# Altium schematic sheet Main_Schematic.SchDoc
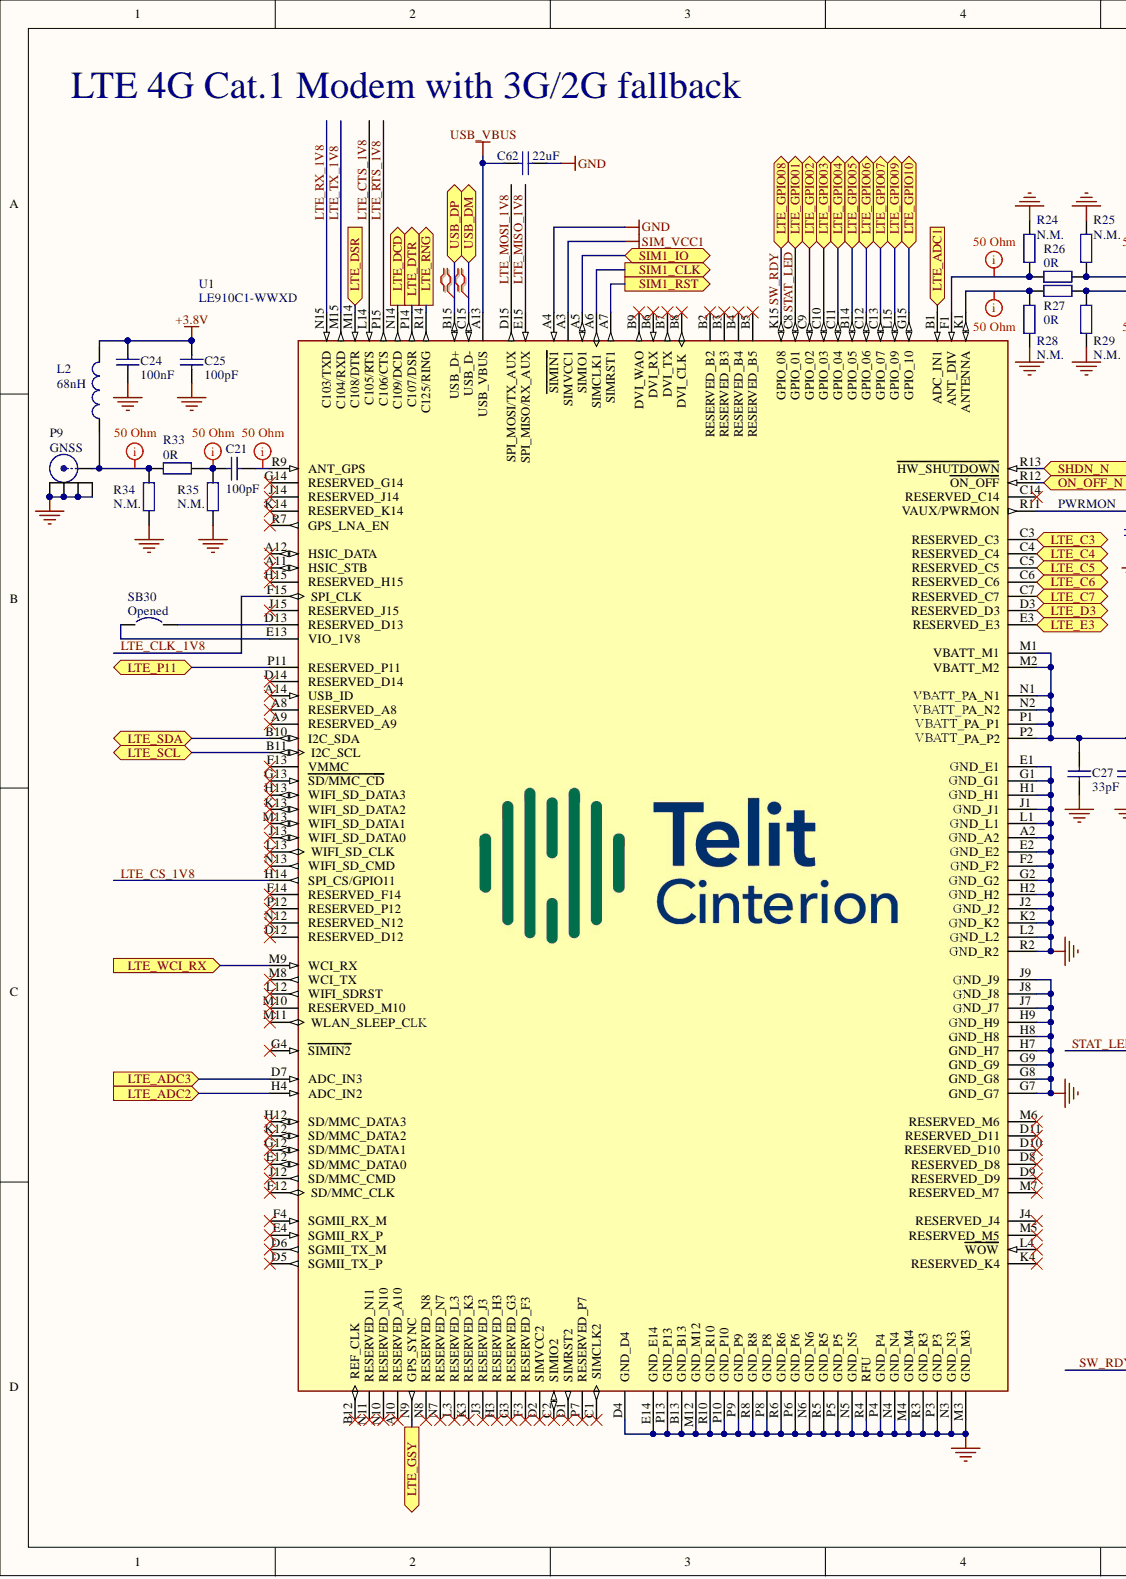
[diagram p0: Main_Schematic.SchDoc, left]
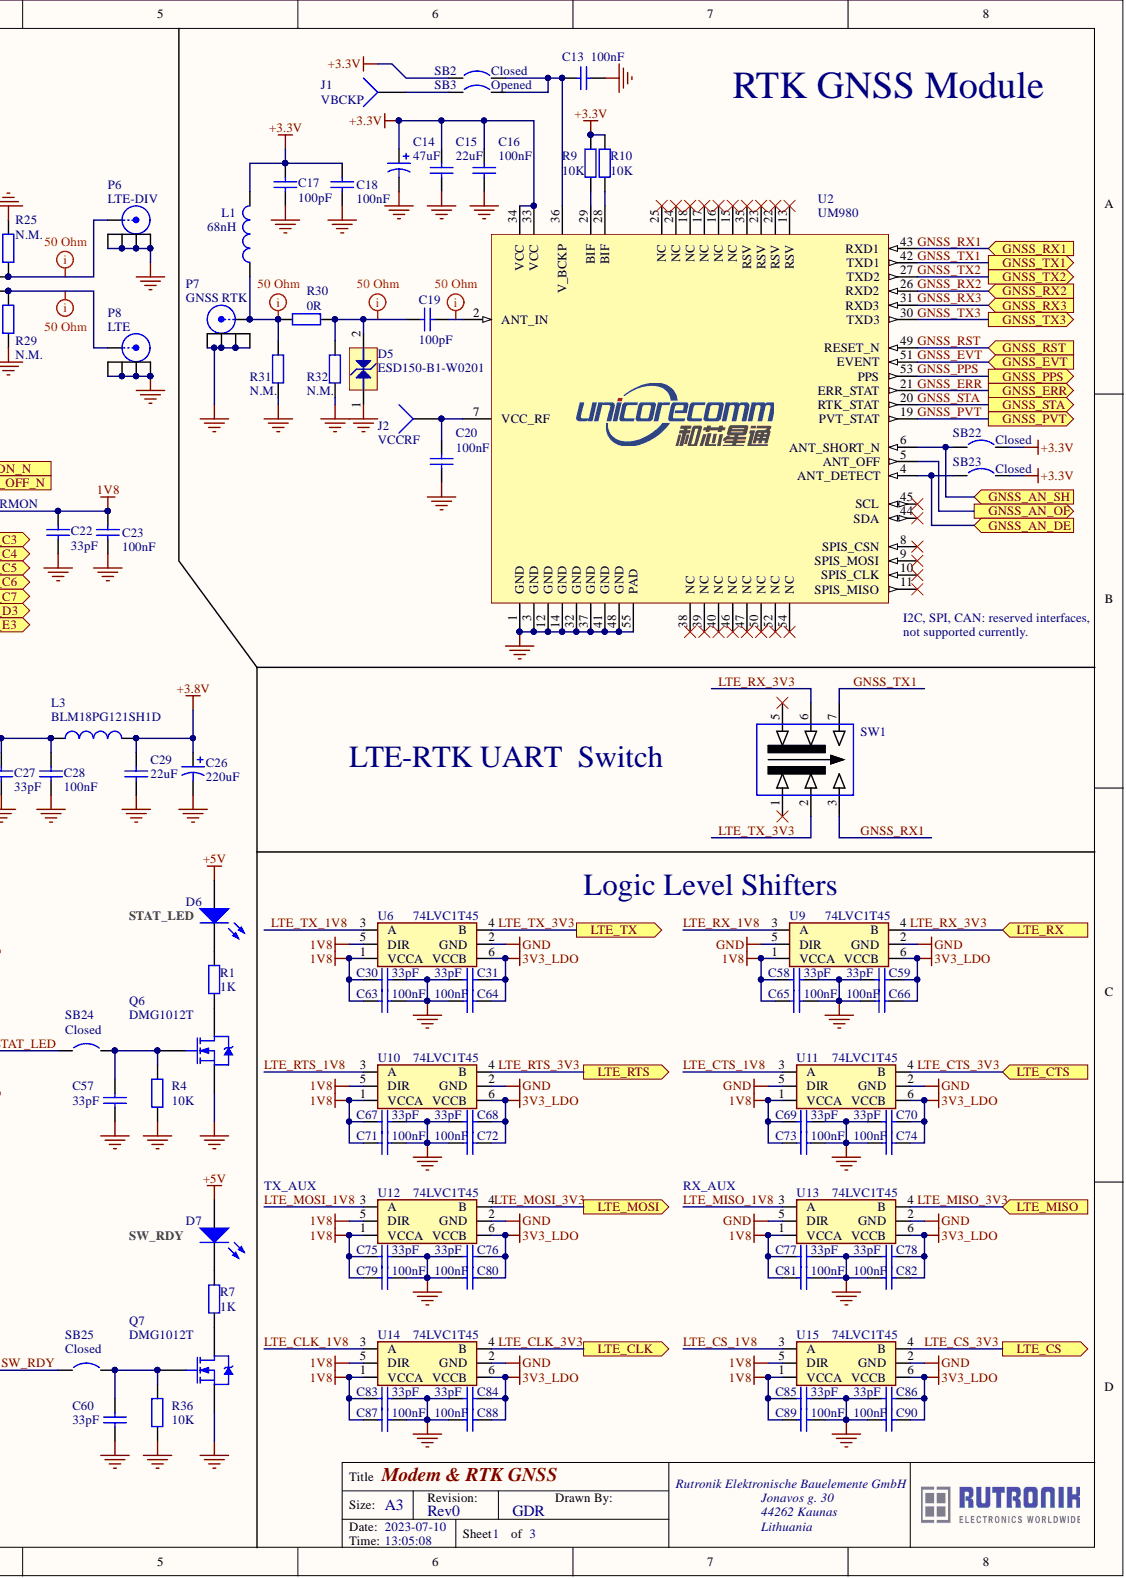
[diagram p1: Main_Schematic.SchDoc, right]

## Parts
C13  value 100nF  [C0402]  (hidden comment: Cap Semi)
C14  value 47uF  [Case-B]
C15  value 22uF  [C0805]  (hidden comment: Cap Semi)
C16  value 100nF  [C0402]  (hidden comment: Cap Semi)
C17  value 100pF  [C0402]  (hidden comment: Cap Semi)
C18  value 100nF  [C0402]  (hidden comment: Cap Semi)
C19  value 100pF  [C0402]  (hidden comment: Cap Semi)
C20  value 100nF  [C0402]  (hidden comment: Cap Semi)
C21  value 100pF  [C0402]  (hidden comment: Cap Semi)
C22  value 33pF  [C0402]  (hidden comment: Cap Semi)
C23  value 100nF  [C0402]  (hidden comment: Cap Semi)
C24  value 100nF  [C0402]  (hidden comment: Cap Semi)
C25  value 100pF  [C0402]  (hidden comment: Cap Semi)
C26  value 220uF  [Case-B]  (hidden comment: electrolytic capacitor)
C27  value 33pF  [C0402]  (hidden comment: Cap Semi)
C28  value 100nF  [C0402]  (hidden comment: Cap Semi)
C29  value 22uF  [C0805]  (hidden comment: Cap Semi)
C30  value 33pF  [C0402]  (hidden comment: Cap Semi)
C31  value 33pF  [C0402]  (hidden comment: Cap Semi)
C57  value 33pF  [C0402]  (hidden comment: Cap Semi)
C58  value 33pF  [C0402]  (hidden comment: Cap Semi)
C59  value 33pF  [C0402]  (hidden comment: Cap Semi)
C60  value 33pF  [C0402]  (hidden comment: Cap Semi)
C62  value 22uF  [C0805]  (hidden comment: Cap Semi)
C63  value 100nF  [C0402]  (hidden comment: Cap Semi)
C64  value 100nF  [C0402]  (hidden comment: Cap Semi)
C65  value 100nF  [C0402]  (hidden comment: Cap Semi)
C66  value 100nF  [C0402]  (hidden comment: Cap Semi)
C67  value 33pF  [C0402]  (hidden comment: Cap Semi)
C68  value 33pF  [C0402]  (hidden comment: Cap Semi)
C69  value 33pF  [C0402]  (hidden comment: Cap Semi)
C70  value 33pF  [C0402]  (hidden comment: Cap Semi)
C71  value 100nF  [C0402]  (hidden comment: Cap Semi)
C72  value 100nF  [C0402]  (hidden comment: Cap Semi)
C73  value 100nF  [C0402]  (hidden comment: Cap Semi)
C74  value 100nF  [C0402]  (hidden comment: Cap Semi)
C75  value 33pF  [C0402]  (hidden comment: Cap Semi)
C76  value 33pF  [C0402]  (hidden comment: Cap Semi)
C77  value 33pF  [C0402]  (hidden comment: Cap Semi)
C78  value 33pF  [C0402]  (hidden comment: Cap Semi)
C79  value 100nF  [C0402]  (hidden comment: Cap Semi)
C80  value 100nF  [C0402]  (hidden comment: Cap Semi)
C81  value 100nF  [C0402]  (hidden comment: Cap Semi)
C82  value 100nF  [C0402]  (hidden comment: Cap Semi)
C83  value 33pF  [C0402]  (hidden comment: Cap Semi)
C84  value 33pF  [C0402]  (hidden comment: Cap Semi)
C85  value 33pF  [C0402]  (hidden comment: Cap Semi)
C86  value 33pF  [C0402]  (hidden comment: Cap Semi)
C87  value 100nF  [C0402]  (hidden comment: Cap Semi)
C88  value 100nF  [C0402]  (hidden comment: Cap Semi)
C89  value 100nF  [C0402]  (hidden comment: Cap Semi)
C90  value 100nF  [C0402]  (hidden comment: Cap Semi)
D5  ESD150-B1-W0201  [SG-WLL-2]
D6  LED  [KG_DELLS_GREEN]  (value not drawn)
D7  LED  [KG_DELLS_GREEN]  (value not drawn)
J1  VBCKP  [PIN1]  (no BOM)
J2  VCCRF  [PIN1]  (no BOM)
L1  value 68nH  [L0402]  (hidden comment: Inductor)
L2  value 68nH  [L0402]  (hidden comment: Inductor)
L3  value BLM18PG121SH1D  Manufacturer Part No.: BLM18PG121SH1D  [L0603]  (hidden comment: Inductor)
P6  LTE-DIV  [73391-0083]
P7  GNSS RTK  [73391-0083]
P8  LTE  [73391-0083]
P9  GNSS  [73391-0083]
Q6  DMG1012T  [SOT-523_custom]
Q7  DMG1012T  [SOT-523_custom]
R1  value 1K  [R0402]  (hidden comment: Res2)
R4  value 10K  [R0402]  (hidden comment: Res2)
R7  value 1K  [R0402]  (hidden comment: Res2)
R9  value 10K  [R0402]  (hidden comment: Res2)
R10  value 10K  [R0402]  (hidden comment: Res2)
R24  value N.M.  [R0402]  (hidden comment: Res2)  (no BOM)
R25  value N.M.  [R0402]  (hidden comment: Res2)  (no BOM)
R26  value 0R  [R0402]  (hidden comment: Res2)
R27  value 0R  [R0402]  (hidden comment: Res2)
R28  value N.M.  [R0402]  (hidden comment: Res2)  (no BOM)
R29  value N.M.  [R0402]  (hidden comment: Res2)  (no BOM)
R30  value 0R  [R0402]  (hidden comment: Res2)
R31  value N.M.  [R0402]  (hidden comment: Res2)  (no BOM)
R32  value N.M.  [R0402]  (hidden comment: Res2)  (no BOM)
R33  value 0R  [R0402]  (hidden comment: Res2)
R34  value N.M.  [R0402]  (hidden comment: Res2)  (no BOM)
R35  value N.M.  [R0402]  (hidden comment: Res2)  (no BOM)
R36  value 10K  [R0402]  (hidden comment: Res2)
SB2  Closed  [SB_0402]
SB3  Opened  [SB_0402 - open]  (no BOM)
SB22  Closed  [SB_0402]
SB23  Closed  [SB_0402]
SB24  Closed  [SB_0402]
SB25  Closed  [SB_0402]
SB30  Opened  [SB_0402 - open]  (no BOM)
SW1  JS202011JCQN  [JS202011JCQN]  (value not drawn)
U1  LE910C1-WWXD  [XCVR_LE910C1WX03T037100]
U2  UM980  [UM980]
U6  74LVC1T45  [X2-DFN1410-6]
U9  74LVC1T45  [X2-DFN1410-6]
U10  74LVC1T45  [X2-DFN1410-6]
U11  74LVC1T45  [X2-DFN1410-6]
U12  74LVC1T45  [X2-DFN1410-6]
U13  74LVC1T45  [X2-DFN1410-6]
U14  74LVC1T45  [X2-DFN1410-6]
U15  74LVC1T45  [X2-DFN1410-6]

## Ports
GNSS_AN_DE, GNSS_AN_OF, GNSS_AN_SH, GNSS_ERR, GNSS_EVT, GNSS_PPS, GNSS_PVT, GNSS_RST, GNSS_RX1, GNSS_RX2, GNSS_RX3, GNSS_STA, GNSS_TX1, GNSS_TX2, GNSS_TX3, LTE_ADC1, LTE_ADC2, LTE_ADC3, LTE_C3, LTE_C4, LTE_C5, LTE_C6, LTE_C7, LTE_CLK, LTE_CS, LTE_CTS, LTE_D3, LTE_DCD, LTE_DSR, LTE_DTR, LTE_E3, LTE_GPIO01, LTE_GPIO02, LTE_GPIO03, LTE_GPIO04, LTE_GPIO05, LTE_GPIO06, LTE_GPIO07, LTE_GPIO08, LTE_GPIO09, LTE_GPIO10, LTE_GSY, LTE_MISO, LTE_MOSI, LTE_P11, LTE_RNG, LTE_RTS, LTE_RX, LTE_SCL, LTE_SDA, LTE_TX, LTE_WCI_RX, ON_OFF_N, SHDN_N, SIM1_CLK, SIM1_IO, SIM1_RST, USB_DM, USB_DP

## Nets
1V8: C22.2 C23.1 C30.2 C58.2 C63.1 C65.1 C67.2 C69.2 C71.1 C73.1 C75.2 C77.2 C79.1 C81.1 C83.2 C85.2 C87.1 C89.1 U1.R11(VAUX/PWRMON) U6.1(VCCA) U6.5(DIR) U9.1(VCCA) U10.1(VCCA) U10.5(DIR) U11.1(VCCA) U12.1(VCCA) U12.5(DIR) U13.1(VCCA) U14.1(VCCA) U14.5(DIR) U15.1(VCCA) U15.5(DIR)
3V3_LDO: C31.1 C59.1 C64.2 C66.2 C68.1 C70.1 C72.2 C74.2 C76.1 C78.1 C80.2 C82.2 C84.1 C86.1 C88.2 C90.2 U6.6(VCCB) U9.6(VCCB) U10.6(VCCB) U11.6(VCCB) U12.6(VCCB) U13.6(VCCB) U14.6(VCCB) U15.6(VCCB)
+3.3V: C14.1 C15.2 C16.1 C17.2 C18.1 L1.2 R9.1 R10.1 SB2.1 SB22.2 SB23.2 U2.33(VCC) U2.34(VCC)
+3.8V: C24.1 C25.2 C26.1 C29.2 L2.2 L3.2
+5V: D6.1 D7.1
GND: C13.2 C14.2 C15.1 C16.2 C17.1 C18.2 C20.2 C22.1 C23.2 C24.2 C25.1 C26.2 C27.1 C28.1 C29.1 C30.1 C31.2 C57.2 C58.1 C59.2 C60.2 C62.1 C63.2 C64.1 C65.2 C66.1 C67.1 C68.2 C69.1 C70.2 C71.2 C72.1 C73.2 C74.1 C75.1 C76.2 C77.1 C78.2 C79.2 C80.1 C81.2 C82.1 C83.1 C84.2 C85.1 C86.2 C87.2 C88.1 C89.2 C90.1 D5.1 P6.2 P6.3 P6.4 P6.5 P7.2 P7.3 P7.4 P7.5 P8.2 P8.3 P8.4 P8.5 P9.2 P9.3 P9.4 P9.5 Q6.3 Q7.3 R4.1 R24.2 R25.2 R28.2 R29.2 R31.2 R32.2 R34.2 R35.2 R36.1 U1.A2(GND_A2) U1.A4(SIMIN1) U1.B13(GND_B13) U1.D4(GND_D4) U1.E1(GND_E1) U1.E2(GND_E2) U1.E14(GND_E14) U1.F2(GND_F2) U1.G1(GND_G1) U1.G2(GND_G2) U1.G7(GND_G7) U1.G8(GND_G8) U1.G9(GND_G9) U1.H1(GND_H1) U1.H2(GND_H2) U1.H7(GND_H7) U1.H8(GND_H8) U1.H9(GND_H9) U1.J1(GND_J1) U1.J2(GND_J2) U1.J7(GND_J7) U1.J8(GND_J8) U1.J9(GND_J9) U1.K2(GND_K2) U1.L1(GND_L1) U1.L2(GND_L2) U1.M3(GND_M3) U1.M4(GND_M4) U1.M12(GND_M12) U1.N3(GND_N3) U1.N4(GND_N4) U1.N5(GND_N5) U1.N6(GND_N6) U1.P3(GND_P3) U1.P4(GND_P4) U1.P5(GND_P5) U1.P6(GND_P6) U1.P8(GND_P8) U1.P9(GND_P9) U1.P10(GND_P10) U1.P13(GND_P13) U1.R2(GND_R2) U1.R3(GND_R3) U1.R4(RFU) U1.R5(GND_R5) U1.R6(GND_R6) U1.R8(GND_R8) U1.R10(GND_R10) U2.1(GND) U2.3(GND) U2.12(GND) U2.14(GND) U2.32(GND) U2.37(GND) U2.41(GND) U2.48(GND) U2.55(PAD) U6.2(GND) U9.2(GND) U9.5(DIR) U10.2(GND) U11.2(GND) U11.5(DIR) U12.2(GND) U13.2(GND) U13.5(DIR) U14.2(GND) U15.2(GND)
SIM_VCC1: U1.A3(SIMVCC1)
USB_VBUS: C62.2 U1.A13(USB_VBUS)
GNSS_AN_DE: SB23.1 U2.4(ANT_DETECT) ; port GNSS_AN_DE
GNSS_AN_OF: U2.5(ANT_OFF) ; port GNSS_AN_OF
GNSS_AN_SH: SB22.1 U2.6(ANT_SHORT_N) ; port GNSS_AN_SH
GNSS_ERR: U2.21(ERR_STAT) ; port GNSS_ERR
GNSS_EVT: U2.51(EVENT) ; port GNSS_EVT
GNSS_PPS: U2.53(PPS) ; port GNSS_PPS
GNSS_PVT: U2.19(PVT_STAT) ; port GNSS_PVT
GNSS_RST: U2.49(RESET_N) ; port GNSS_RST
GNSS_RX1: SW1.3 U2.43(RXD1) ; port GNSS_RX1
GNSS_RX2: U2.26(RXD2) ; port GNSS_RX2
GNSS_RX3: U2.31(RXD3) ; port GNSS_RX3
GNSS_STA: U2.20(RTK_STAT) ; port GNSS_STA
GNSS_TX1: SW1.7 U2.42(TXD1) ; port GNSS_TX1
GNSS_TX2: U2.27(TXD2) ; port GNSS_TX2
GNSS_TX3: U2.30(TXD3) ; port GNSS_TX3
LTE_ADC1: U1.B1(ADC_IN1) ; port LTE_ADC1
LTE_ADC2: U1.H4(ADC_IN2) ; port LTE_ADC2
LTE_ADC3: U1.D7(ADC_IN3) ; port LTE_ADC3
LTE_C3: U1.C3(RESERVED_C3) ; port LTE_C3
LTE_C4: U1.C4(RESERVED_C4) ; port LTE_C4
LTE_C5: U1.C5(RESERVED_C5) ; port LTE_C5
LTE_C6: U1.C6(RESERVED_C6) ; port LTE_C6
LTE_C7: U1.C7(RESERVED_C7) ; port LTE_C7
LTE_CLK_1V8: U1.F15(SPI_CLK) U14.3(A)
LTE_CLK_3V3: U14.4(B) ; port LTE_CLK
LTE_CS_1V8: U1.H14(SPI_CS/GPIO11) U15.3(A)
LTE_CS_3V3: U15.4(B) ; port LTE_CS
LTE_CTS_1V8: U1.L14(C105/RTS) U11.3(A)
LTE_CTS_3V3: U11.4(B) ; port LTE_CTS
LTE_D3: U1.D3(RESERVED_D3) ; port LTE_D3
LTE_DCD: U1.N14(C109/DCD) ; port LTE_DCD
LTE_DSR: U1.M14(C108/DTR) ; port LTE_DSR
LTE_DTR: U1.P14(C107/DSR) ; port LTE_DTR
LTE_E3: U1.E3(RESERVED_E3) ; port LTE_E3
LTE_GPIO02: U1.C9(GPIO_02) ; port LTE_GPIO02
LTE_GPIO03: U1.C10(GPIO_03) ; port LTE_GPIO03
LTE_GPIO04: U1.C11(GPIO_04) ; port LTE_GPIO04
LTE_GPIO05: U1.B14(GPIO_05) ; port LTE_GPIO05
LTE_GPIO06: U1.C12(GPIO_06) ; port LTE_GPIO06
LTE_GPIO07: U1.C13(GPIO_07) ; port LTE_GPIO07
LTE_GPIO09: U1.L15(GPIO_09) ; port LTE_GPIO09
LTE_GPIO10: U1.G15(GPIO_10) ; port LTE_GPIO10
LTE_GSY: U1.N9(GPS_SYNC) ; port LTE_GSY
LTE_MISO_1V8: U1.E15(SPI_MISO/RX_AUX) U13.3(A)
LTE_MISO_3V3: U13.4(B) ; port LTE_MISO
LTE_MOSI_1V8: U1.D15(SPI_MOSI/TX_AUX) U12.3(A)
LTE_MOSI_3V3: U12.4(B) ; port LTE_MOSI
LTE_P11: U1.P11(RESERVED_P11) ; port LTE_P11
LTE_RNG: U1.R14(C125/RING) ; port LTE_RNG
LTE_RTS_1V8: U1.P15(C106/CTS) U10.3(A)
LTE_RTS_3V3: U10.4(B) ; port LTE_RTS
LTE_RX_1V8: U1.N15(C103/TXD) U9.3(A)
LTE_RX_3V3: SW1.6 U9.4(B) ; port LTE_RX
LTE_SCL: U1.B11(I2C_SCL) ; port LTE_SCL
LTE_SDA: U1.B10(I2C_SDA) ; port LTE_SDA
LTE_TX_1V8: U1.M15(C104/RXD) U6.3(A)
LTE_TX_3V3: SW1.2 U6.4(B) ; port LTE_TX
LTE_WCI_RX: U1.M9(WCI_RX) ; port LTE_WCI_RX
ON_OFF_N: U1.R12(ON_OFF) ; port ON_OFF_N
SHDN_N: U1.R13(HW_SHUTDOWN) ; port SHDN_N
SIM1_CLK: U1.A6(SIMCLK1) ; port SIM1_CLK
SIM1_IO: U1.A5(SIMIO1) ; port SIM1_IO
SIM1_RST: U1.A7(SIMRST1) ; port SIM1_RST
STAT_LED: SB24.1 U1.C8(GPIO_01) ; port LTE_GPIO01
SW_RDY: SB25.1 U1.K15(GPIO_08) ; port LTE_GPIO08
USB_DM: U1.C15(USB_D-) ; port USB_DM
USB_DP: U1.B15(USB_D+) ; port USB_DP
NetC13_1: C13.1 SB2.2 SB3.2 U2.36(V_BCKP)
NetC19_1: C19.1 D5.2 R30.1 R32.1
NetC19_2: C19.2 U2.2(ANT_IN)
NetC20_1: C20.1 J2.1 U2.7(VCC_RF)
NetC21_1: C21.1 R33.1 R35.1
NetC21_2: C21.2 U1.R9(ANT_GPS)
NetC27_2: C27.2 C28.2 L3.1 U1.M1(VBATT_M1) U1.M2(VBATT_M2) U1.N1(VBATT_PA_N1) U1.N2(VBATT_PA_N2) U1.P1(VBATT_PA_P1) U1.P2(VBATT_PA_P2)
NetC57_1: C57.1 Q6.1 R4.2 SB24.2
NetC60_1: C60.1 Q7.1 R36.2 SB25.2
NetD6_2: D6.2 R1.2
NetD7_2: D7.2 R7.2
NetJ1_1: J1.1 SB3.1
NetL1_1: L1.1 P7.1 R30.2 R31.1
NetL2_1: L2.1 P9.1 R33.2 R34.1
NetP6_1: P6.1 R25.1 R26.2
NetP8_1: P8.1 R27.1 R29.1
NetQ6_2: Q6.2 R1.1
NetQ7_2: Q7.2 R7.1
NetR9_2: R9.2 U2.29(BIF)
NetR10_2: R10.2 U2.28(BIF)
NetR24_1: R24.1 R26.1 U1.F1(ANT_DIV)
NetR27_2: R27.2 R28.1 U1.K1(ANTENNA)
NetSB30_1: SB30.1 U1.E13(VIO_1V8)
NetSB30_2: SB30.2 U1.D13(RESERVED_D13)

Not connected: SW1 1 5; U1 A8(RESERVED_A8) A9(RESERVED_A9) A10(RESERVED_A10) A11(HSIC_STB) A12(HSIC_DATA) A14(USB_ID) B2(RESERVED_B2) B3(RESERVED_B3) B4(RESERVED_B4) B5(RESERVED_B5) B6(DVI_RX) B7(DVI_TX) B8(DVI_CLK) B9(DVI_WAO) B12(REF_CLK) C1(SIMCLK2) C2(SIMIO2) C14(RESERVED_C14) D1(SIMRST2) D2(SIMVCC2) D5(SGMII_TX_P) D6(SGMII_TX_M) D8(RESERVED_D8) D9(RESERVED_D9) D10(RESERVED_D10) D11(RESERVED_D11) D12(RESERVED_D12) D14(RESERVED_D14) E4(SGMII_RX_P) E12(SD/MMC_DATA0) F3(RESERVED_F3) F4(SGMII_RX_M) F12(SD/MMC_CLK) F13(VMMC) F14(RESERVED_F14) G3(RESERVED_G3) G4(SIMIN2) G12(SD/MMC_DATA1) G13(SD/MMC_CD) G14(RESERVED_G14) H3(RESERVED_H3) H12(SD/MMC_DATA3) H13(WIFI_SD_DATA3) H15(RESERVED_H15) J3(RESERVED_J3) J4(RESERVED_J4) J12(SD/MMC_CMD) J13(WIFI_SD_DATA0) J14(RESERVED_J14) J15(RESERVED_J15) K3(RESERVED_K3) K4(RESERVED_K4) K12(SD/MMC_DATA2) K13(WIFI_SD_DATA2) K14(RESERVED_K14) L3(RESERVED_L3) L4(WOW) L12(WIFI_SDRST) L13(WIFI_SD_CLK) M5(RESERVED_M5) M6(RESERVED_M6) M7(RESERVED_M7) M8(WCI_TX) M10(RESERVED_M10) M11(WLAN_SLEEP_CLK) M13(WIFI_SD_DATA1) N7(RESERVED_N7) N8(RESERVED_N8) N10(RESERVED_N10) N11(RESERVED_N11) N12(RESERVED_N12) N13(WIFI_SD_CMD) P7(RESERVED_P7) P12(RESERVED_P12) R7(GPS_LNA_EN); U2 8(SPIS_CSN) 9(SPIS_MOSI) 10(SPIS_CLK) 11(SPIS_MISO) 13(RSV) 15(NC) 16(NC) 17(NC) 18(NC) 22(RSV) 23(RSV) 24(NC) 25(NC) 35(RSV) 38(NC) 39(NC) 40(NC) 44(SDA) 45(SCL) 46(NC) 47(NC) 50(NC) 52(NC) 54(NC)

## Library data of the parts
C13 C16 C18 C20 C23 C24 = Cap Semi: Capacitor (Semiconductor SIM Model); Manufacturer: TDK; Manufacturer Part No.: CGA2B3X7R1H104K050BB; Part Description: Ceramic Capacitor; Specification: X7R, 50V, 10%; Component Kind: Standard; Library Name: Miscellaneous Devices.IntLib; Link: https://www.rutronik24.com/product/tdk/cga2b3x7r1h104k050bb/15441483.html; Signal Integrity: Cap Semi; Simulation: CAP; Supplier: Rutronik; Supplier part number: KKA1277
C14 = Cap Pol1: Manufacturer: AVX; Manufacturer Part No.: NOJB476M006RWJ; Part Description: Niobium Oxide Capacitor; Specification: 6V, 20%; Component Kind: Standard; Library Name: Miscellaneous Devices.IntLib; Link: https://www.rutronik24.com/product/avx/nojb476m006rwj/11635687.html; Signal Integrity: Cap Pol; Simulation: CAP; Supplier: Rutronik; Supplier part number: KTA6684
C15 C29 C62 = Cap Semi: Capacitor (Semiconductor SIM Model); Manufacturer: Samsung EM; Manufacturer Part No.: CL21A226KQQNNNG; Part Description: Ceramic Capacitor; Specification: X5R, 6.3V, 10%; Component Kind: Standard; Library Name: Miscellaneous Devices.IntLib; Link: https://www.rutronik24.com/product/samsung+em/cl21a226kqqnnng/32257.html; Signal Integrity: Cap Semi; Simulation: CAP; Supplier: Rutronik; Supplier part number: KKS2632
C17 C19 C21 C25 = Cap Semi: Capacitor (Semiconductor SIM Model); Part Description: Ceramic Capacitor; Specification: NP0, 50V, 5%; Component Kind: Standard; Library Name: Miscellaneous Devices.IntLib; Signal Integrity: Cap Semi; Simulation: CAP; Supplier: Rutronik
C22 C27 C30 C31 C57-C60 C67-C70 C75-C78 C83-C86 = Cap Semi: Capacitor (Semiconductor SIM Model); Manufacturer: AVX; Manufacturer Part No.: 04025A330JAT2A; Part Description: Ceramic Capacitor; Specification: NP0, 50V, 5%; Component Kind: Standard; Library Name: Miscellaneous Devices.IntLib; Link: https://www.rutronik24.com/product/avx/04025a330jat2a/3692730.html; Signal Integrity: Cap Semi; Simulation: CAP; Supplier: Rutronik; Supplier part number: KKK13594
C26 = Cap Pol1: Manufacturer: AVX; Manufacturer Part No.: TPSB107M006R0250; Part Description: Tantalum Capacitor; Specification: 6,3V 20%; Component Kind: Standard; Library Name: Miscellaneous Devices.IntLib; Link: https://www.rutronik24.com/search-result/qs:TPSB107M006R0250%20/reset:0; Signal Integrity: Cap Pol; Simulation: CAP; Supplier: Rutronik; Supplier part number: TPSB107M006R0250
C28 C63-C66 C71-C74 C79-C82 C87-C90 = Cap Semi: Capacitor (Semiconductor SIM Model); Manufacturer: YAGEO; Manufacturer Part No.: CC0402KRX7R9BB104; Part Description: Ceramic Capacitor; Specification: X7R, 50V, 10%; Component Kind: Standard; Library Name: Miscellaneous Devices.IntLib; Link: https://www.rutronik24.com/search-result/qs:KKK23619/reset:0; Signal Integrity: Cap Semi; Simulation: CAP; Supplier: Rutronik; Supplier part number: KKK23619
D5 = ESD_Diode_Bidirectional: Bidirectional ESD Diode Symbol; Component Kind: Standard; Part Description: ESD Diode; Supplier: Rutronik; Value: ESD150-B1-W0201; Library Name: RutDevKit-PSoC62.SCHLIB
D6 D7 = LED2: Typical SMD LED; Manufacturer: OSRAM; Manufacturer Part No.: KGDELLS1.22-JGKH-24-0-2-S; Part Description: TOPLED® E1608; Specification: GREEN, 20mA; Value: KGDELLS1.22-JGKH-24-0-2-S; Component Kind: Standard; Library Name: Miscellaneous Devices.IntLib; Link: https://www.rutronik24.com/search-result/qs:KG%20DELLS1.22/reset:0; Simulation: LED2; Supplier: Rutronik; Supplier part number: LEDATV1791
J1 J2 = Socket: Description: Socket; Library Name: Miscellaneous Connectors.IntLib; Signal Integrity: Connector
L3 = Inductor: Manufacturer: muRata; Part Description: Ferrite Bead; Specification: 120R, 2A; Component Kind: Standard; Description: Inductor; Library Name: Miscellaneous Devices.IntLib; Link: https://www.rutronik24.com/product/murata/blm18pg121sh1d/5885588.html; Signal Integrity: Inductor; Simulation: INDUCTOR; Supplier: Rutronik; Supplier part number: FE3867
P6-P9 = SMB: Specification: Fermale; Value: 73391-0083; Component Kind: Standard; Supplier: Rutronik; Library Name: RAB_RTK.SCHLIB
Q6 Q7 = NMOS-2: N-Channel Power MOSFET; Manufacturer: Diodes Inc.; Manufacturer Part No.: DMG1012T-7; Part Description: N-Channel MOSFET; Specification: 20V, 0.63A; Value: DMG1012T-7; Component Kind: Standard; Library Name: Miscellaneous Devices.IntLib; Link: https://www.rutronik24.com/product/diodes+inc/dmg1012t-7/5168665.html; Simulation: IRF1010; Supplier: Rutronik; Supplier part number: TMOSS6623
R1 R7 = Res2: Manufacturer: Yageo; Manufacturer Part No.: RC0201JR-071KL; Part Description: Thick Film Resistor; Specification: 5% 0,05W; Component Kind: Standard; Library Name: Miscellaneous Devices.IntLib; Link: https://www.rutronik24.com/product/yageo/rc0201jr-071kl/58401.html; Signal Integrity: Res; Simulation: RESISTOR; Supplier: Rutronik; Supplier part number: WRC50692
R4 R9 R10 R36 = Res2: Manufacturer: ASJ; Manufacturer Part No.: CR10-103-JK; Part Description: Thick Film Resistor; Specification: 5% 0,063W; Component Kind: Standard; Library Name: Miscellaneous Devices.IntLib; Link: https://www.rutronik24.com/product/asj/cr10-103-jk/54295.html; Signal Integrity: Res; Simulation: RESISTOR; Supplier: Rutronik; Supplier part number: WRC40976
R24 R29 R32 R35 = Res2: Part Description: Thick Film Resistor; Rule: Short-Circuit Constraint [Short Circuit - Allowed]; Specification: 5% 0,063W; Library Name: Miscellaneous Devices.IntLib; Signal Integrity: Res; Simulation: RESISTOR; Supplier: Rutronik
R25 R28 R31 R34 = Res2: Part Description: Thick Film Resistor; Specification: 5% 0,063W; Library Name: Miscellaneous Devices.IntLib; Signal Integrity: Res; Simulation: RESISTOR; Supplier: Rutronik
R26 R27 R30 R33 = Res2: Manufacturer: Yageo; Manufacturer Part No.: RC0402FR-070RL; Part Description: Thick Film Resistor; Rule: Short-Circuit Constraint [Short Circuit - Allowed]; Specification: 5% 0,063W; Component Kind: Standard; Library Name: Miscellaneous Devices.IntLib; Link: https://www.rutronik24.com/search-result/qs:WRC53079/reset:0; Signal Integrity: Res; Simulation: RESISTOR; Supplier: Rutronik; Supplier part number: WRC53079
SB2 SB3 SB22-SB25 SB30 = Circuit Breaker_close: Circuit Breaker; Manufacturer: Yageo; Manufacturer Part No.: AC0402JR-130RL; Part Description: Thick Film Resistor; Specification: 5% 0,063W; Value: 0R; Link: https://www.rutronik24.com/product/yageo/ac0402jr-130rl/1878226.html; Revision: July-2002: Re-released for DXP Platform.; Supplier: Rutronik; Supplier part number: WRC53073; Library Name: RutAppKit-LedDriverSensor.SCHLIB
  SB2 SB22-SB25: Component Kind: Standard
SW1 = JS202011JCQN: Sub-Miniature Slide Switch, VERTICAL MOUNT SMT - J BEND; Manufacturer: C&K; Specification: 6V DC, 0.3A; Component Kind: Standard; Library Name: JS202011JCQN.SchLib; Link: https://www.rutronik24.com/search-result/nojs:1337/qs:JS202011JCQN/reset:0; Manufacturer Part No.: JS202011JCQN; Part Description: VERTICAL MOUNT SMT - J BEND; Supplier: Rutronik; Supplier part number: SWITCH10809; Value: JS202011JCQN
U1 = LE910C1-WWXD: LTE Category 1 module Global variant; Component Kind: Standard; Library Name: LE910C1-WWXD.SchLib
U2 = UM980: All-constellation Multi-frequency High Precision RTK Positioning Module; Component Kind: Standard; Library Name: UM980.SchLib
U6 U9-U15 = 74LVC1T45: Manufacturer: Diodes Inc.; Manufacturer Part No.: 74LVC1T45FZ4-7; Part Description: Single Bit Voltage Level Translator; Specification: 5.5V, 1.65V; Value: 74LVC1T45FZ4-7; Component Kind: Standard; Link: https://www.rutronik24.com/product/diodes+inc/74lvc1t45w6-7/7622634.html; Supplier: Rutronik; Supplier part number: ICLOG10709; Library Name: RAB_RTK.SCHLIB
L1 L2 = Inductor: Component Kind: Standard; Description: Inductor; Library Name: Miscellaneous Devices.IntLib; Part Description: Inductor; Signal Integrity: Inductor; Simulation: INDUCTOR; Supplier: Rutronik
